# Altium schematic sheet IMU.SchDoc
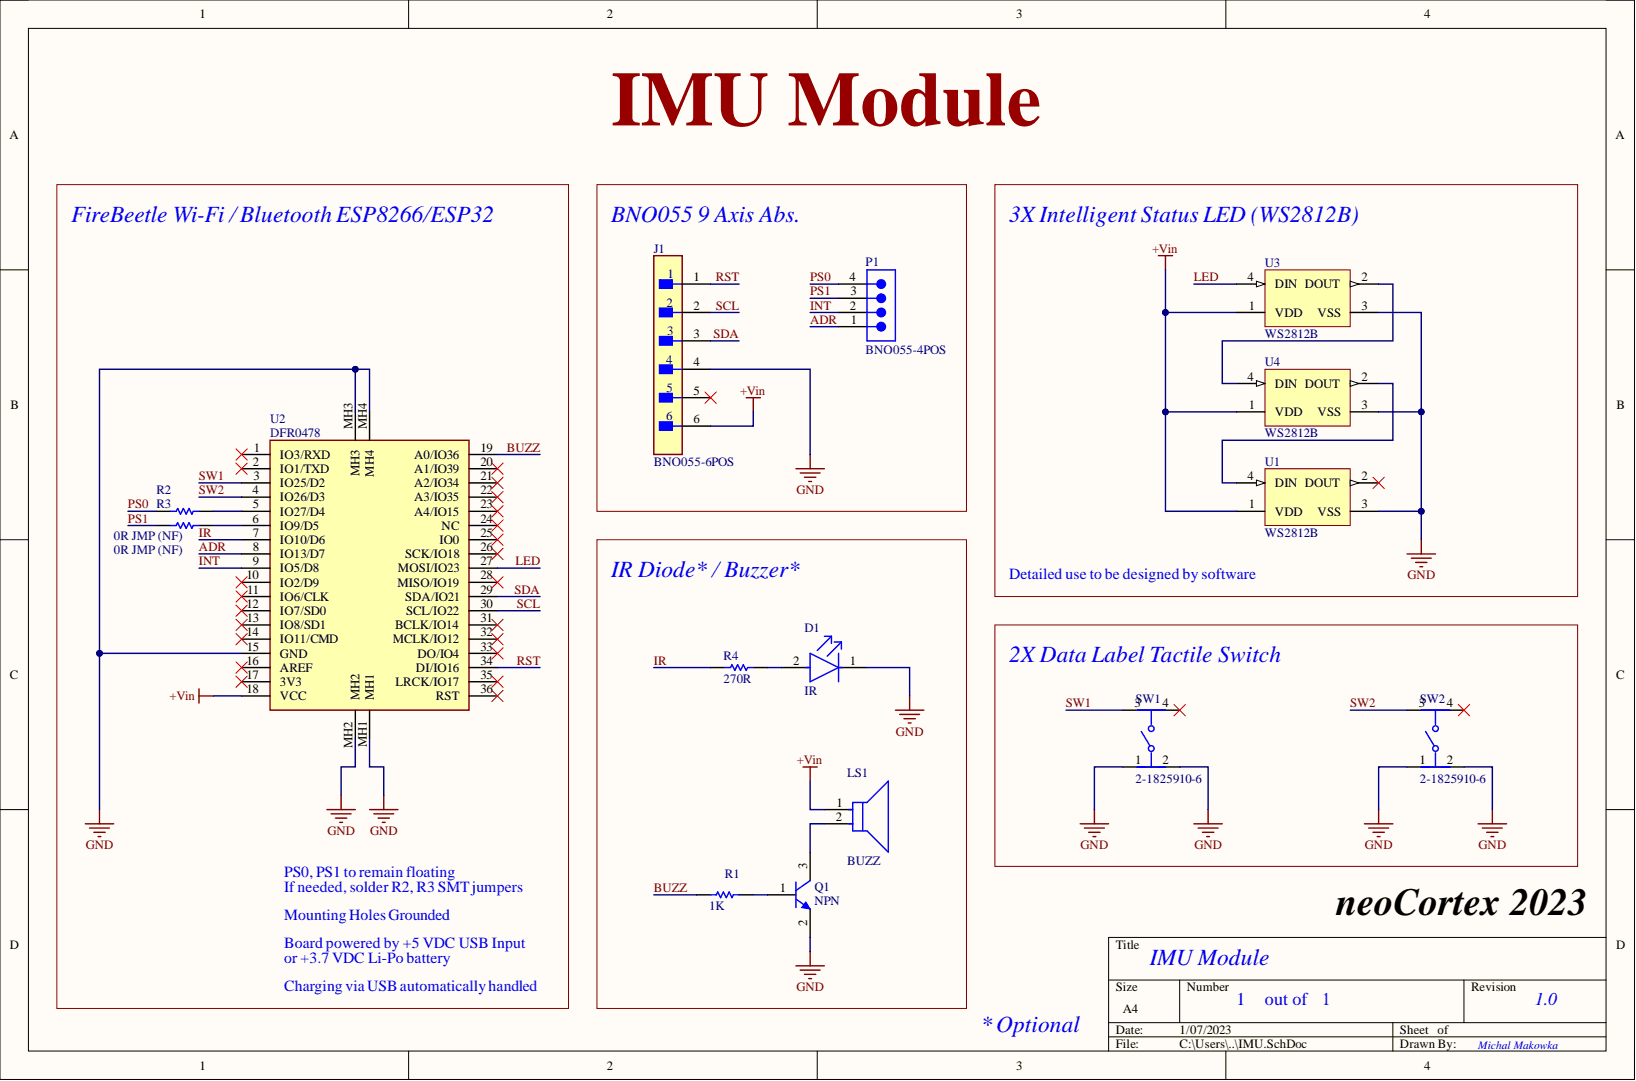
[diagram p0: IMU.SchDoc]

## Parts
D1  IR  [FP-6_544-5259_08-4-MFG]
J1  BNO055-6POS  [FP-HTSW-106-07-G-S-MFG]
LS1  BUZZ  [FP-MCKPX-G1203A-K4056-MFG]
P1  BNO055-4POS  [SMTC-TSW-104-08-X-S]
Q1  NPN  [ONSC-SOT-23-3-318-08_V]
R1  1K  [RESC2013X60X35ML10T20]
R2  0R JMP (NF)  [RESC1005X40X25NL05T10]  (no BOM)
R3  0R JMP (NF)  [RESC1005X40X25NL05T10]  (no BOM)
R4  270R  [RESC2013X50X30ML20T20]
SW1  2-1825910-6  [FP-2-1825910-6-MFG]
SW2  2-1825910-6  [FP-2-1825910-6-MFG]
U1  WS2812B  [ADA-RGB-1655_V]
U2  DFR0478  [FIREBEETLE_ESP32]
U3  WS2812B  [ADA-RGB-1655_V]
U4  WS2812B  [ADA-RGB-1655_V]

## Nets
+Vin: J1.6 LS1.1 U1.1(VDD) U2.18(VCC) U3.1(VDD) U4.1(VDD)
GND: D1.1 J1.4 Q1.2 SW1.1 SW1.2 SW2.1 SW2.2 U1.3(VSS) U2.15(GND) U2.MH1 U2.MH2 U2.MH3 U2.MH4 U3.3(VSS) U4.3(VSS)
ADR: P1.1 U2.8(IO13/D7)
BUZZ: R1.1 U2.19(A0/IO36)
INT: P1.2 U2.9(IO5/D8)
IR: R4.1 U2.7(IO10/D6)
LED: U2.27(MOSI/IO23) U3.4(DIN)
PS0: P1.4 R2.1
PS1: P1.3 R3.1
RST: J1.1 U2.34(DI/IO16)
SCL: J1.2 U2.30(SCL/IO22)
SDA: J1.3 U2.29(SDA/IO21)
SW1: SW1.3 U2.3(IO25/D2)
SW2: SW2.3 U2.4(IO26/D3)
NetD1_2: D1.2 R4.2
NetLS1_2: LS1.2 Q1.3
NetQ1_1: Q1.1 R1.2
NetR2_2: R2.2 U2.5(IO27/D4)
NetR3_2: R3.2 U2.6(IO9/D5)
NetU1_4: U1.4(DIN) U4.2(DOUT)
NetU3_2: U3.2(DOUT) U4.4(DIN)

Not connected: J1 5; SW1 4; SW2 4; U1 2(DOUT); U2 1(IO3/RXD) 2(IO1/TXD) 10(IO2/D9) 11(IO6/CLK) 12(IO7/SD0) 13(IO8/SD1) 14(IO11/CMD) 16(AREF) 17(3V3) 20(A1/IO39) 21(A2/IO34) 22(A3/IO35) 23(A4/IO15) 24(NC) 25(IO0) 26(SCK/IO18) 28(MISO/IO19) 31(BCLK/IO14) 32(MCLK/IO12) 33(DO/IO4) 35(LRCK/IO17) 36(RST)

## Library data of the parts
D1 = CMP-02520-000005-1: EMITTER IR 940NM 100MA RADIAL; Manufacturer: Vishay; Manufacturer Part Number: TSAL6100; Colour: Infrared; Forward Voltage: 1.35V; Led Orientation: Straight; Max Junction Temp: +100°C; Power Dissipation: 160mW; Reverse Voltage: 5V; Viewing Angle: 20°; Ambient Temperature Range High: +85°C; Ambient Temperature Range Low: -40°C; Automotive: No; Category: Diode; Component Kind: Standard; ComponentLink2Description: Datasheet; ComponentLink2URL: http://www.vishay.com/docs/81009/tsal6100.pdf; Device Class L1: Optoelectronics; Device Class L2: LEDs; Device Class L3: unset; Digikey Description: EMITTER IR 940NM 100MA RADIAL; Forward Current: 100mA; Ipc Land Pattern Name: DIORD254W110D580H900; Lead Free: Yes; Library Name: Altium Content Vault; Mouser Description: TSAL6100 Series 950 nm 100 mA Through Hole Infrared Emitting Diode - T-1 3/4; Octopart: https://octopart.com/tsal6100-vishay-39643276; Package: 6.544-5259.08-4; Peak Emmision Wavelength: 940nm; RoHS: TRUE; Temperature: -40°C to +85°C; Temperature Range High: +85°C; Temperature Range Low: -40°C
J1 = CMP-02766-001515-1: CONN HEADER VERT 6POS 2.54MM; Gender: Male; Max Current Rating: 7.6A; Max Voltage Rating (AC): 550V; Number of Contacts: 6; Pitch: 0.1inch; Color: Natural; Component Kind: Standard; Connector Type: Header; Contact Finish - Mating: GOLD; Contact Material: Bronze; Contact Mating Length: 0.23inch; Contact Plating: Gold; Contact Shape: Square; Contact Type: Male Pin; Depth: 2.48mm; Fastening Type: Pull; Flammability Rating: UL94 V-0; Housing Color: Natural; Housing Material: Polymer; Insulation Color: Natural; Insulation Material: Polymer; Lead Free: Lead Free; Length: 15.24mm; Library Name: Altium Content Vault; Max Operating Temperature: 125°C; Min Operating Temperature: -55°C; Mount: Through Hole; Number of Positions: 6; Number of Rows: 1; Orientation: Straight; Packaging: Rail/Tube; Radiation Hardening: No; REACH SVHC: No SVHC; RoHS Compliant: Yes; Series: HTSW; Termination: Solder
LS1 = CMP-12433-000001-1: MAGNETIC BUZZER AND TRANSDUCER - More Details; Manufacturer: Multicomp Pro; Manufacturer Part Number: MCKPX-G1203A-K4056; Current: 30mA; Frequency: 2300Hz; Max Supply Voltage: 5V; Min Supply Voltage: 2V; Operating Supply Voltage: 3V; Standoff Height: 0.5mm; Automotive: No; Category: Speak; Component Kind: Standard; ComponentLink2Description: Datasheet; ComponentLink2URL: http://www.farnell.com/datasheets/1498149.pdf?_ga=2.17855225.1157923166.1572356775-536200964.1569240579; Device Class L1: Electromechanical; Device Class L2: Audio Products; Device Class L3: Buzzers; Height: 9.5mm; Lead Free: Yes; Library Name: Altium Content Vault; Octopart: https://octopart.com/mckpx-g1203a-k4056-multicomp-12157154; Package: 0; RoHS: TRUE; Temperature: -20°C to +70°C; Temperature Range High: +70°C; Temperature Range Low: -20°C
P1 = CMP-1024-00356-1: 0.025" SQ Post Header, Through-hole, Vertical, -55 to 105 degC, 2.54 mm Pitch, 4-Pin, Male, RoHS; Manufacturer: Samtec; PartNumber: TSW-104-08-T-S; Pitch: 2.54 mm; Component Kind: Standard; ComponentLink1Description: Manufacturer URL; ComponentLink1URL: http://www.samtec.com; ComponentLink2Description: Datasheet; ComponentLink2URL: http://www.samtec.com/documents/webfiles/pdf/TSW_TH.PDF; ComponentLink3Description: Package Specification; ComponentLink3URL: http://www.samtec.com/documents/webfiles/cpdf/TSW-XXX-XX-XXX-X-XX-XXX-MKT.pdf; DatasheetVersion: F-214; Library Name: Altium Content Vault; Mounting Technology: Through-hole; Orientation: Vertical; PackageDescription: .025[0.64] SQ. Post Terminal Strip Assembly; PackageReference: TSW-104-08-X-S; PackageVersion: DM, 8/1988; RoHS: True; Temperature Range: -55 to 105 degC
Q1 = CMP-1048-00662-1: High Voltage Transistor, NPN Silicon, 3-Pin SOT-23, Pb-Free, Tape and Reel; Manufacturer: On Semiconductor; PartNumber: MMBTA42LT1G; fT Min (MHz): 50; IC Continuous (A): 0.5; Component Kind: Standard; ComponentLink1Description: Manufacturer URL; ComponentLink1URL: http://www.onsemi.com/; ComponentLink2Description: Datasheet; ComponentLink2URL: http://www.onsemi.cn/pub_link/Collateral/MMBTA42LT1-D.PDF; ComponentLink3Description: Package Specification; ComponentLink3URL: http://www.onsemi.cn/pub_link/Collateral/318-08.PDF; DatasheetVersion: Rev. 12; Library Name: Altium Content Vault; Mounting Technology: Surface Mount; PackageDescription: 3-Pin Small Outline Transistor, Body 2.92 x 1.3 mm, Pitch 0.95 mm; PackageReference: SOT-23-3-318-08; PackageVersion: Rev. AP, 11/2009; Packing: Tape and Reel; Polarity: NPN; RoHS: TRUE
R1 = CMP-2100-03671-1: Chip Resistor, 1 KOhm, +/- 1%, 125 mW, -55 to 155 degC, 0805 (2012 Metric), RoHS, Tape and Reel; Manufacturer: Yageo; Max Power Dissipation: 125mW; Resistance: 1KOhm; Temperature Coefficient: 100ppm/degreesC; Voltage Rating: 150V; Case Code (Imperial): 0805; Case Code (Metric): 2012; Case/Package: 0805; Component Kind: Standard; Composition: ThickFilm; Datasheet URL: http://www.yageo.com/NewPortal/yageodocoutput?fileName=/pdf/R-Chip/PYu-RC_Group_51_RoHS_L_5.pdf; Datasheet Version: Rev. 5, 10/2016; Depth: 1.25mm; Height: 0.024inch; Lead Free: LeadFree; Length: 0.079inch; Library Name: Altium Content Vault; Manufacturer URL: http://www.yageo.com/; Max Operating Temperature: 155degC; Military Standard: Not; Min Operating Temperature: -55degC; Number of Pins: 2; Number of Terminations: 2; Package Description: 2-Pin Surface Mounted Device, Body 2 x 1.25 mm; Package Quantity: 1; Package Reference: 0805; Packaging: TapeandReel; Power Rating: 125mW; REACH SVHC: Unknown; RoHSCompliant: true; Termination: SMD/SMT; Tolerance: 1%; Voltage Rating (DC): 150V; Width: 0.049inch
R2 R3 = CMP-1011-00001-2: Manufacturer: Yageo / Phycomp; Part Number: RC0402JR-070RL; Library Name: Altium Content Vault
R4 = CMP-2001-03735-1: Manufacturer: Vishay Dale; Part Number: CRCW0805270RFKEA; Component Kind: Standard; Library Name: Altium Content Vault
SW1 SW2 = CMP-03407-000025-1: SWITCH TACTILE SPST-NO 0.05A 24V; Actuator Color: Brown; Actuator Length: 0.37inch; Actuator Material: Nylon; Actuator Type: Standard; Contact Current Rating: 50mA; Illumination Type: Non-Illuminated; Mechanical Life: 100000Cycles; Operating Force: 100gf; Switch Function: Off-Mom; Switch Travel: 0.25mm; Throw Configuration: SPST; Component Kind: Standard; Contact Material: Copper Alloy; Contact Plating: Silver; ELV: Compliant; Lead Free: Lead Free; Length: 5.99mm; Library Name: Altium Content Vault; Max Current Rating: 50mA; Max Operating Temperature: 85°C; Min Operating Temperature: -35°C; Mount: Through Hole; Orientation: Straight; Packaging: Loose Piece; RoHS Compliant: Yes; Series: FSMJ; Termination: PC Pin; Type: Switch; Voltage Rating (DC): 24V
U1 U3 U4 = CMP-2000-05026-1: Intelligent Control LED Integrated Light Source, 3.5 to 5.3 V, -25 to 80 degC, 4-Pin SMD, RoHS, Tape and Reel; Manufacturer: Adafruit-Industries-LLC; Configuration: Discrete; Number of LEDs: 10; Utilized LED: WS2812(5050); Category: Optoelectronics; Color: Red,Green,Blue(RGB); Component Kind: Standard; Controller Type: -; Datasheet URL: http://datasheet.octopart.com/ADA1655-Adafruit-Industries-datasheet-32028139.pdf; Datasheet Version: May-13; Family: Addressable,Specialty; Interface: -; Library Name: Altium Content Vault; Manufacturer URL: https://www.adafruit.com/; Mounting Type: SurfaceMount; Package Description: 4-Pin Surface Mount Device, Body 5 x 5 mm; Package Reference: 1655; Series: Neopixel; Size / Dimension: 5.00mmLx5.00mmW
U2 = DFR0478: Undefined or Miscellaneous; Manufacturer_Name: DFRobot; Arrow Part Number: DFR0478; Arrow Price/Stock: https://www.arrow.com/en/products/dfr0478/dfrobot?region=nac; Component Kind: Standard; Datasheet Link: https://datasheet.datasheetarchive.com/originals/distributors/DKDS-1/13356.pdf; Manufacturer_Part_Number: DFR0478; Mouser Part Number: 426-DFR0478; Mouser Price/Stock: https://www.mouser.co.uk/ProductDetail/DFRobot/DFR0478?qs=EU6FO9ffTwc%252BR65h2NUGQw%3D%3D
